# Altium schematic sheet logic switch.SchDoc
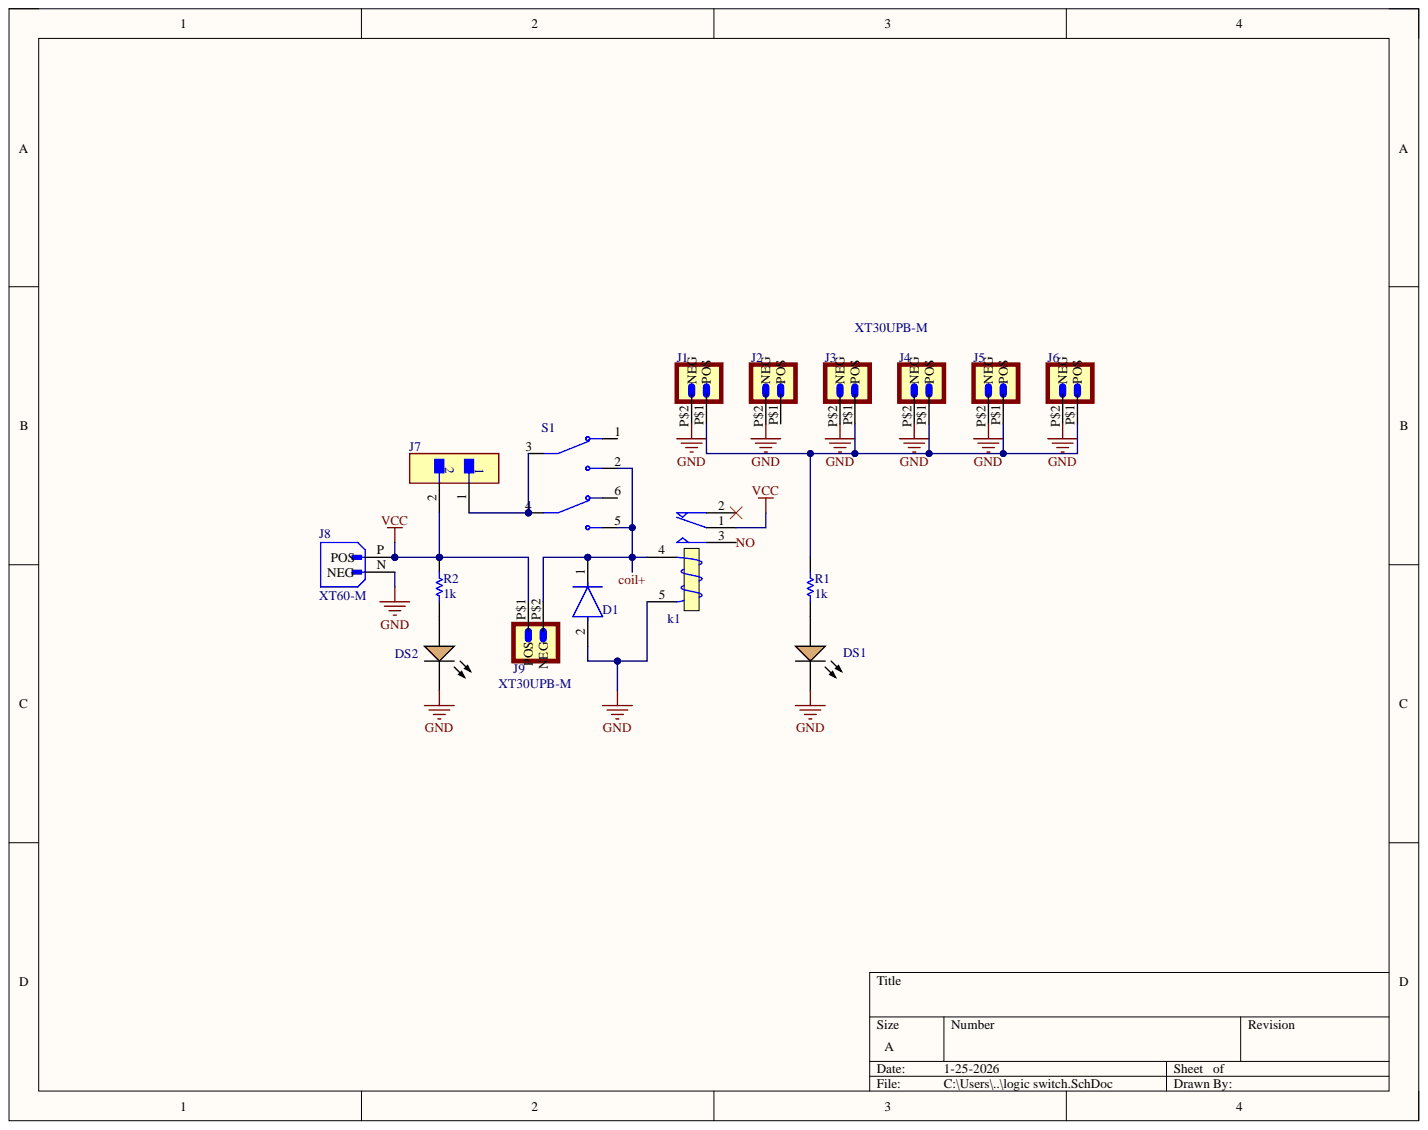
[diagram p0: logic switch.SchDoc]

## Parts
D1  BAV103-GS18  [FP-6_560-5005_01-4-MFG]  (value not drawn)
DS1  HSMA-C150  [AVAG-HSMX-C150_V]  (value not drawn)
DS2  HSMA-C150  [AVAG-HSMX-C150_V]  (value not drawn)
J1  XT30UPB-M  [XT30UPB-M]
J2  XT30UPB-M  [XT30UPB-M]
J3  XT30UPB-M  [XT30UPB-M]
J4  XT30UPB-M  [XT30UPB-M]
J5  XT30UPB-M  [XT30UPB-M]
J6  XT30UPB-M  [XT30UPB-M]
J7  PEC02SABN  [FP-PEC02SABN-MFG]  (value not drawn)
J8  XT60-M  [AMASS_XT60-M]
J9  XT30UPB-M  [XT30UPB-M]
k1  Relay 5pin T90 12V  [REL_30A_T90]  (value not drawn)
R1  1k  [RESC2013X60X35ML10T20]
R2  1k  [RESC2013X60X35ML10T20]
S1  TL2285OA  [SW_TL2285OA]  (value not drawn)

## Nets
GND: D1.2 DS1.2 DS2.2 J1.P$2 J2.P$2 J3.P$2 J4.P$2 J5.P$2 J6.P$2 J8.N k1.5
VCC: J7.2 J8.P J9.P$1 k1.1 R2.1
coil+: D1.1 J9.P$2 k1.4 S1.2 S1.5
NO: k1.3
NetDS1_1: DS1.1 R1.2
NetDS2_1: DS2.1 R2.2
NetJ1_P$1: J1.P$1 J3.P$1 J4.P$1 J5.P$1 J6.P$1 R1.1
NetJ7_1: J7.1 S1.3 S1.4

Not connected: J2 P$1; k1 2; S1 1 6

## Library data of the parts
D1 = CMP-02540-000035-1: DIODE GEN PURP 200V 250MA SOD80; Manufacturer: Vishay Semiconductor Diodes Division; Automotive Grade: Grade 0; Capacitance: 1.5pF; Max Junction Temp: +175°C; Max Repetitive Reverse Voltage: 250V; Max Surge Forward Current: 1A; Peak Reverse Current: 0.1uA; Value: 1.5pF; Automotive: Yes; Category: Diode; Component Kind: Standard; ComponentLink2Description: Datasheet; ComponentLink2URL: http://www.vishay.com/docs/85542/bav100.pdf; Device Class L1: Discrete Semiconductors; Device Class L2: Diodes; Device Class L3: Rectifier Diodes; Digikey Description: DIODE GEN PURP 200V 250MA SOD80; Forward Current: 250mA; Height: 1.6mm; Ipc Land Pattern Name: DIOMELF350150; Lead Free: Yes; Library Name: Altium Content Vault; Manufacturer Part Number: BAV103-GS18; Mouser Description: Diode Small Signal Switching 250V 0.25A Automotive 2-Pin Mini-MELF SOD-80 T/R; Octopart: https://octopart.com/bav103-gs18-vishay-55507560; Package: 6.560-5005.01-4; Reverse Voltage: 200V; RoHS: TRUE; Temperature: to +175°C; Temperature Range High: +175°C
DS1 DS2 = CMP-2000-06420-1: High Performance Chip LED, 60 mW, 25 mA, -30 to 85 degC, 2-Pin SMD, RoHS, Tape and Reel; Brand: AvagoTechnologies; Forward Current: 20mA; Forward Voltage: 1.9V; Illumination Color: Amber; LED Size: 3.2mmx1.6mmx1.1mm; Lens Color/Style: Diffused; Luminous Intensity: 90mcd; Power Rating: 60mW; Viewing Angle: 170deg; Wavelength/Color Temperature: 592nm; Component Kind: Standard; Datasheet URL: https://datasheet.ciiva.com/29280/180503-da-01-en-chip-led-hsma-c150-gelb-29280992.pdf; Datasheet Version: 03/2008; Factory Pack Quantity: 3000; Library Name: Altium Content Vault; Manufacturer: AvagoTechnologies; Manufacturer URL: http://www.avagotech.com/; Maximum Operating Temperature: +85C; Minimum Operating Temperature: -40C; Mounting Technology: SurfaceMount; Package / Case: 3.2mmx1.6mmx1.1mm; Package Description: 2-Pin Surface Mount Device, Body 3.2 x 1.6 mm; Package Reference: HSMX-C150; Packaging: Reel; Product Category: StandardLEDs-SMD; RoHS: Details
J1-J6 J9 = XT30UPB-M: Socket; DC supply; XT30; male; PIN: 2; on PCBs; THT; yellow; 15A; 500V; MF: amass; Availability: Not in stock; Check_prices: https://www.snapeda.com/parts/XT30UPB-M/AMASS/view-part/?ref=eda; Component Kind: Standard; Library Name: XT30UPB-M (1).IntLib; MP: XT30UPB-M; Package: None; Price: None; SnapEDA_Link: https://www.snapeda.com/parts/XT30UPB-M/AMASS/view-part/?ref=snap
J7 = CMP-25680-000076-1: CONN HEADER VERT 2POS 2.54MM; Connector Type: Male Pin; Gender: Male; Number of Contacts: 2; Pitch: 0.1inch; Color: Black; Component Kind: Standard; Contact Finish - Mating: TIN; Contact Material: Bronze; Contact Mating Length: 0.23inch; Contact Plating: Tin; Contact Shape: Square; Contact Type: Male Pin; Fastening Type: Pull; Flammability Rating: UL94 V-0; Height: 2.54mm; Housing Color: Black; Housing Material: Plastic; Insulation Color: Black; Insulation Material: PBT; Lead Free: Lead Free; Length: 5.08mm; Library Name: Altium Content Vault; Max Operating Temperature: 125°C; Min Operating Temperature: -65°C; Mount: Through Hole; Number of Positions: 2; Number of Rows: 1; Package Quantity: 1; Packaging: Bulk; RoHS Compliant: Yes; Series: -; Termination: Solder; Width: 2.54mm
J8 = XT60-M: Plug; DC supply; XT60; male; PIN: 2; for cable; soldered; 30A; 500V; MF: AMASS; Check_prices: https://www.snapeda.com/parts/XT60-M/AMASS/view-part/?ref=eda; Component Kind: Standard; Library Name: XT60-M.IntLib; MP: XT60-M; Package: Package; SnapEDA_Link: https://www.snapeda.com/parts/XT60-M/AMASS/view-part/?ref=snap
k1 = Relay 5pin T90 12V: PartNumber: CMP7-S-DC12V-C; Component Kind: Standard; Library Name: Relay (2).IntLib
R1 R2 = CMP-2100-03673-1: Chip Resistor, 0 Ohm, Jumper, +/- 5%, 0.125 W, -55 to 155 degC, 0805 (2012 Metric), RoHS, Tape and Reel; Manufacturer: Yageo; Power Rating: 125mW; Resistance: 0mOhm; Temperature Coefficient: 200ppm/degreesC; Voltage Rating: 150V; Case Code (Imperial): 0805; Case Code (Metric): 2012; Case/Package: 0805; Component Kind: Standard; Composition: ThickFilm; Contact Plating: Tin; Datasheet URL: http://www.yageo.com/NewPortal/yageodocoutput?fileName=/pdf/R-Chip/PYu-RC_Group_51_RoHS_L_5.pdf; Datasheet Version: Rev. 5, 10/2016; Depth: 1.25mm; Height: 0.5mm; Lead Free: LeadFree; Length: 2mm; Library Name: Altium Content Vault; Manufacturer URL: http://www.yageo.com/; Max Operating Temperature: 155degC; Military Standard: Not; Min Operating Temperature: -55degC; Number of Pins: 2; Package Description: 2-Pin Surface Mounted Device, Body 2 x 1.25 mm; Package Reference: 0805; Packaging: TapeandReel; Radiation Hardening: No; REACH SVHC: Unknown; RoHSCompliant: true; Termination: SMD/SMT; Tolerance: 5%; Voltage Rating (DC): 150V; Width: 1.25mm
S1 = TL2285OA: Pushbutton Switch, TL2285, DPDT, ON-(ON), Plunger for Cap, 100mA, 30VDC,PC Pin | E-Switch TL2285OA; Check_prices: https://www.snapeda.com/parts/TL2285OA/E-Switch/view-part/?ref=eda; Component Kind: Standard; Library Name: TL2285OA (1).IntLib; MF: E-Switch; MP: TL2285OA; Package: None; SnapEDA_Link: https://www.snapeda.com/parts/TL2285OA/E-Switch/view-part/?ref=snap
